# Altium schematic sheet SensorExpanderBoard.SchDoc
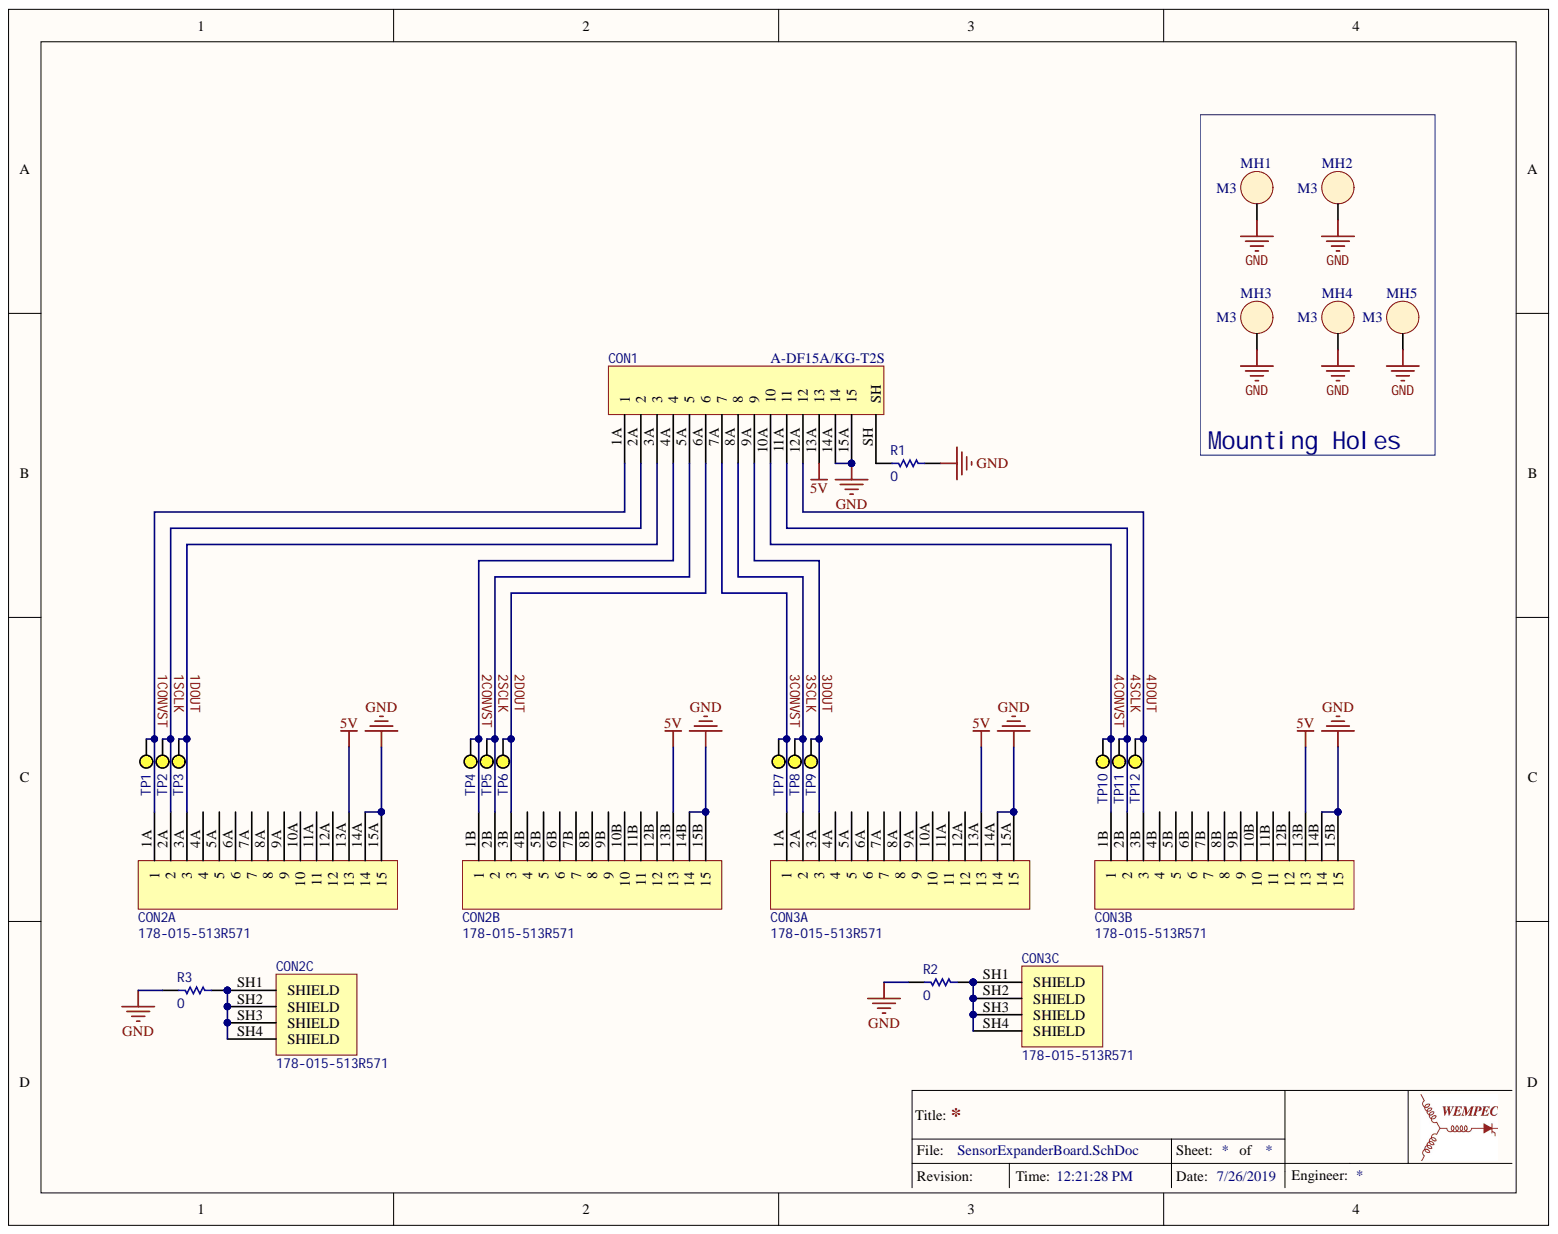
[diagram p0: SensorExpanderBoard.SchDoc]

## Parts
*  TitleBlockWEMPEC  (value not drawn)
CON1  Current Rating: 3A  Manufacturer Part Number: A-DF15A/KG-T2S  [A-DF 15 A/KG-T2S]  (hidden comment: CON - A-DF 15 A/KG-T2S)
CON2  178-015-513R571  Current Rating: 5A  [178-015-513R571]  (parts A,B,C)
CON3  178-015-513R571  Current Rating: 5A  [178-015-513R571]  (parts A,B,C)
MH1  M3  [MOUNTING HOLE - M3]
MH2  M3  [MOUNTING HOLE - M3]
MH3  M3  [MOUNTING HOLE - M3]
MH4  M3  [MOUNTING HOLE - M3]
MH5  M3  [MOUNTING HOLE - M3]
R1  ERJ-3GEY0R00V  [RESC1608X55X30NL15T15]  (value not drawn)
R2  ERJ-3GEY0R00V  [RESC1608X55X30NL15T15]  (value not drawn)
R3  ERJ-3GEY0R00V  [RESC1608X55X30NL15T15]  (value not drawn)
TP1  5004  [KSTN-5004]  (value not drawn)
TP2  5004  [KSTN-5004]  (value not drawn)
TP3  5004  [KSTN-5004]  (value not drawn)
TP4  5004  [KSTN-5004]  (value not drawn)
TP5  5004  [KSTN-5004]  (value not drawn)
TP6  5004  [KSTN-5004]  (value not drawn)
TP7  5004  [KSTN-5004]  (value not drawn)
TP8  5004  [KSTN-5004]  (value not drawn)
TP9  5004  [KSTN-5004]  (value not drawn)
TP10  5004  [KSTN-5004]  (value not drawn)
TP11  5004  [KSTN-5004]  (value not drawn)
TP12  5004  [KSTN-5004]  (value not drawn)

## Nets
5V: CON1.13A CON2.13A CON2.13B CON3.13A CON3.13B
GND: CON1.14A CON1.15A CON2.14A CON2.14B CON2.15A CON2.15B CON3.14A CON3.14B CON3.15A CON3.15B MH1.1 MH2.1 MH3.1 MH4.1 MH5.1 R1.2 R2.1 R3.1
1CONVST: CON1.1A CON2.1A TP1.1
1DOUT: CON1.3A CON2.3A TP3.1
1SCLK: CON1.2A CON2.2A TP2.1
2CONVST: CON1.4A CON2.1B TP4.1
2DOUT: CON1.6A CON2.3B TP6.1
2SCLK: CON1.5A CON2.2B TP5.1
3CONVST: CON1.7A CON3.1A TP7.1
3DOUT: CON1.9A CON3.3A TP9.1
3SCLK: CON1.8A CON3.2A TP8.1
4CONVST: CON1.10A CON3.1B TP10.1
4DOUT: CON1.12A CON3.3B TP12.1
4SCLK: CON1.11A CON3.2B TP11.1
NetCON1_SH: CON1.SH R1.1
NetCON2_SH1: CON2.SH1(SHIELD) CON2.SH2(SHIELD) CON2.SH3(SHIELD) CON2.SH4(SHIELD) R3.2
NetCON3_SH1: CON3.SH1(SHIELD) CON3.SH2(SHIELD) CON3.SH3(SHIELD) CON3.SH4(SHIELD) R2.2

Not connected: CON2 4A 4B 5A 5B 6A 6B 7A 7B 8A 8B 9A 9B 10A 10B 11A 11B 12A 12B; CON3 4A 4B 5A 5B 6A 6B 7A 7B 8A 8B 9A 9B 10A 10B 11A 11B 12A 12B

## Library data of the parts
CON1 = CON - A-DF 15 A/KG-T2S: CONN D-SUB RCPT 15POS R/A SOLDER; Manufacturer: Assmann; Max Supply Voltage (AC): 250V; Number of Ports: 1; Number of Positions: 15; Color: Black; Component Kind: Standard; ComponentLink1Description: https://datasheet.ciiva.com/26927/getdatasheetpartid-755447-26927443.pdf?src-supplier=Verical; ComponentLink1URL: https://datasheet.ciiva.com/26927/getdatasheetpartid-755447-26927443.pdf?src-supplier=Verical; Connector Type: D-Sub; Contact Material: Brass; Contact Plating: Gold; Depth: 18.6mm; Housing Material: PBT; Length: 39.2mm; Library Name: SensorCard.SchLib; Max Operating Temperature: 105°C; Min Operating Temperature: -55°C; Mount: Through Hole; Number of Pins: 15; Number of Rows: 2; Number of Terminals: 15; Orientation: Right Angle; Packaging: Tray; Radiation Hardening: No; RoHS: Yes; Stock 1: 0; Supplier 1: Digi-Key; Supplier Part Number 1: AE10929-ND; Termination: Solder; Voltage Rating: 250V
CON2 CON3 = 178-015-513R571: CONN D-SUB RCPT 15POS R/A SLDR; Manufacturer: NorComp; Body Material: Steel; Connector Type: D-Sub,Receptacle,Signal; Gender: Female to Female; Number of Contacts: 15; Plating: Tin; Colour: Black; Component Kind: Standard; ComponentLink1Description: https://datasheet.ciiva.com/16342/178-yyy-513r571-16342488.pdf; ComponentLink1URL: https://datasheet.ciiva.com/16342/178-yyy-513r571-16342488.pdf; ComponentLink2Description: https://datasheet.ciiva.com/656/178-yyy-513r571-656074.pdf; ComponentLink2URL: https://datasheet.ciiva.com/656/178-yyy-513r571-656074.pdf; Contact Material: Brass; Contact Plating: Gold; Features: Shielded; Flammability Rating: UL94 V-0; Height: 31.8mm; Housing Colour: Black; Housing Material: Nylon; Lead Free: Lead Free; Length: 39mm; Library Name: SensorCard.SchLib; Manufacturer Part Number: 178-015-513R571; Material: Metal; Max Operating Temperature: 105°C; Min Operating Temperature: -55°C; Mount: Through Hole,Edge; Number of Positions: 15; Number of Rows: 2; Package Quantity: 35; Packaging: Tray; Pricing 1: 1=9.34, 10=8.932, 25=8.12, 50=7.714, 100=7.511, 250=6.699, 500=6.293, 1000=5.5622 (USD); REACH SVHC: No SVHC; Shell Material: Tin; Stock 1: 6279; Supplier 1: Digi-Key; Supplier Part Number 1: 1115FFE-ND; Termination: Through Hole; Width: 25.4mm
MH1-MH5 = MOUNT_HOLE: MOUNTING HOLE; Component Kind: Standard; Library Name: SensorCard.SchLib
R1-R3 = CMP-2000-00700-1: Manufacturer: Panasonic; Max Power Dissipation: 100mW; Resistance: 0; Temperature Coefficient: 600ppm/°C; Tolerance: 0%; Voltage Rating: 75V; Voltage Rating (DC): 50V; Case/Package: 0603; Case Code (Imperial): 0603; Case Code (Metric): 1608; Component Kind: Standard; ComponentLink1Description: https://datasheet.ciiva.com/11808/0900766b80f806dd-11808207.pdf?src-supplier=RSComponents; ComponentLink1URL: https://datasheet.ciiva.com/11808/0900766b80f806dd-11808207.pdf?src-supplier=RSComponents; ComponentLink2Description: https://datasheet.ciiva.com/27055/1935748-27055113.pdf?src-supplier=Jameco; ComponentLink2URL: https://datasheet.ciiva.com/27055/1935748-27055113.pdf?src-supplier=Jameco; ComponentLink3Description: https://datasheet.ciiva.com/48532/aoa0000ce1-48532165.pdf?src-supplier=Avnet; ComponentLink3URL: https://datasheet.ciiva.com/48532/aoa0000ce1-48532165.pdf?src-supplier=Avnet; ComponentLink4Description: https://datasheet.ciiva.com/43460/1678796-43460647.pdf?src-supplier=Farnell; ComponentLink4URL: https://datasheet.ciiva.com/43460/1678796-43460647.pdf?src-supplier=Farnell; ComponentLink5Description: https://datasheet.ciiva.com/28695/1678796-28695833.pdf?src-supplier=Element14; ComponentLink5URL: https://datasheet.ciiva.com/28695/1678796-28695833.pdf?src-supplier=Element14; ComponentLink6Description: https://datasheet.ciiva.com/5959/1678796-5959707.pdf?src-supplier=Farnell; ComponentLink6URL: https://datasheet.ciiva.com/5959/1678796-5959707.pdf?src-supplier=Farnell; ComponentLink7Description: https://datasheet.ciiva.com/46377/1678796-46377868.pdf?src-supplier=Element14; ComponentLink7URL: https://datasheet.ciiva.com/46377/1678796-46377868.pdf?src-supplier=Element14; ComponentLink8Description: https://datasheet.ciiva.com/30199/4aoa0000ce1-30199822.pdf?src-supplier=Onlinecomponents.com; ComponentLink8URL: https://datasheet.ciiva.com/30199/4aoa0000ce1-30199822.pdf?src-supplier=Onlinecomponents.com; ComponentLink9Description: https://datasheet.ciiva.com/16758/70255313-16758527.pdf?src-supplier=Allied+Electronics; ComponentLink9URL: https://datasheet.ciiva.com/16758/70255313-16758527.pdf?src-supplier=Allied+Electronics; ComponentLink10Description: https://datasheet.ciiva.com/16834/aoa0000ce1-16834828.pdf?src-supplier=Future+Electronics; ComponentLink10URL: https://datasheet.ciiva.com/16834/aoa0000ce1-16834828.pdf?src-supplier=Future+Electronics; ComponentLink11Description: https://datasheet.ciiva.com/17215/aoa0000ce1-17215388.pdf?src-supplier=Avnet+Europe; ComponentLink11URL: https://datasheet.ciiva.com/17215/aoa0000ce1-17215388.pdf?src-supplier=Avnet+Europe; ComponentLink12Description: https://datasheet.ciiva.com/9198/aoa0000ce1-9198975.pdf?src-supplier=Avnet; ComponentLink12URL: https://datasheet.ciiva.com/9198/aoa0000ce1-9198975.pdf?src-supplier=Avnet; Composition: Thick Film; Contact Plating: Tin; Depth: 0.8mm; Features: Automotive AEC-Q200; Height: 0.45mm; Lead Free: Lead Free; Length: 1.6mm; Library Name: Altium Content Vault; Manufacturer Part Number: ERJ-3GEY0R00V; Max Operating Temperature: 155°C; Military Standard: Not; Min Operating Temperature: -55°C; Mount: Surface Mount; Number of Terminations: 2; Package Quantity: 1; Packaging: Tape and Reel; Part Number: ERJ-3GEY0R00V; Power Rating: 100mW; Pricing 1: 1=0.10000, 10=0.04200, 25=0.03040, 50=0.02320, 100=0.01710, 250=0.01308, 500=0.01046, 1000=0.00770 (USD); Radiation Hardening: No; REACH SVHC: No SVHC; RoHS: Yes; Stock 1: 31571560; Supplier 1: Digi-Key; Supplier Part Number 1: P0.0GCT-ND; Termination: SMD/SMT; Width: 0.031inch
TP1-TP12 = CMP-2000-05575-1: Test Point, Yellow, 1-Pin THD, RoHS, Bulk; Manufacturer: Keystone; Body Material: PBT; Inside Diameter: 1.27mm; Number of Ports: 1; Outside Diameter: 2.54mm; Plating: Silver; Color: Yellow; Component Kind: Standard; ComponentLink1Description: https://datasheet.ciiva.com/2917/5000-5004-2917632.pdf?src-supplier=Digi-Key; ComponentLink1URL: https://datasheet.ciiva.com/2917/5000-5004-2917632.pdf?src-supplier=Digi-Key; ComponentLink2Description: https://datasheet.ciiva.com/16507/m60-2p60-16507925.pdf?src-supplier=Future+Electronics; ComponentLink2URL: https://datasheet.ciiva.com/16507/m60-2p60-16507925.pdf?src-supplier=Future+Electronics; ComponentLink3Description: https://datasheet.ciiva.com/1454/1703983-1454827.pdf?src-supplier=Element14; ComponentLink3URL: https://datasheet.ciiva.com/1454/1703983-1454827.pdf?src-supplier=Element14; Contact Material: Bronze; Contact Plating: Silver; Datasheet URL: http://www.keyelco.com/product-pdf.cfm?p=1313; Datasheet Version: Rev. G, 12/2008; Flammability Rating: UL94 V-0; Height: 0.3inch; Housing Color: Yellow; Lead Free: Lead Free; Length: 7.62mm; Library Name: Altium Content Vault; Manufacturer Part Number: 5004; Manufacturer URL: http://www.keyelco.com/; Material: Nylon; Mount: Through Hole; Mounting Technology: ThroughHole; Orientation: Straight; Package Description: 1-Pin Through Hole Device, Dia 2.54 mm; Package Quantity: 10; Package Reference: 5004; Packaging: Bulk; Pricing 1: 1=0.35000, 10=0.33500, 50=0.24380, 250=0.21032, 1000=0.17140, 2500=0.15296, 5000=0.14340 (USD); REACH SVHC: No SVHC; RoHS: Yes; Stock 1: 164959; Supplier 1: Digi-Key; Supplier Part Number 1: 36-5004-ND
* = TitleBlockWEMPEC: Component Kind: Standard; Library Name: SensorCard.SchLib
